# Altium schematic sheet MCU_IO.SchDoc
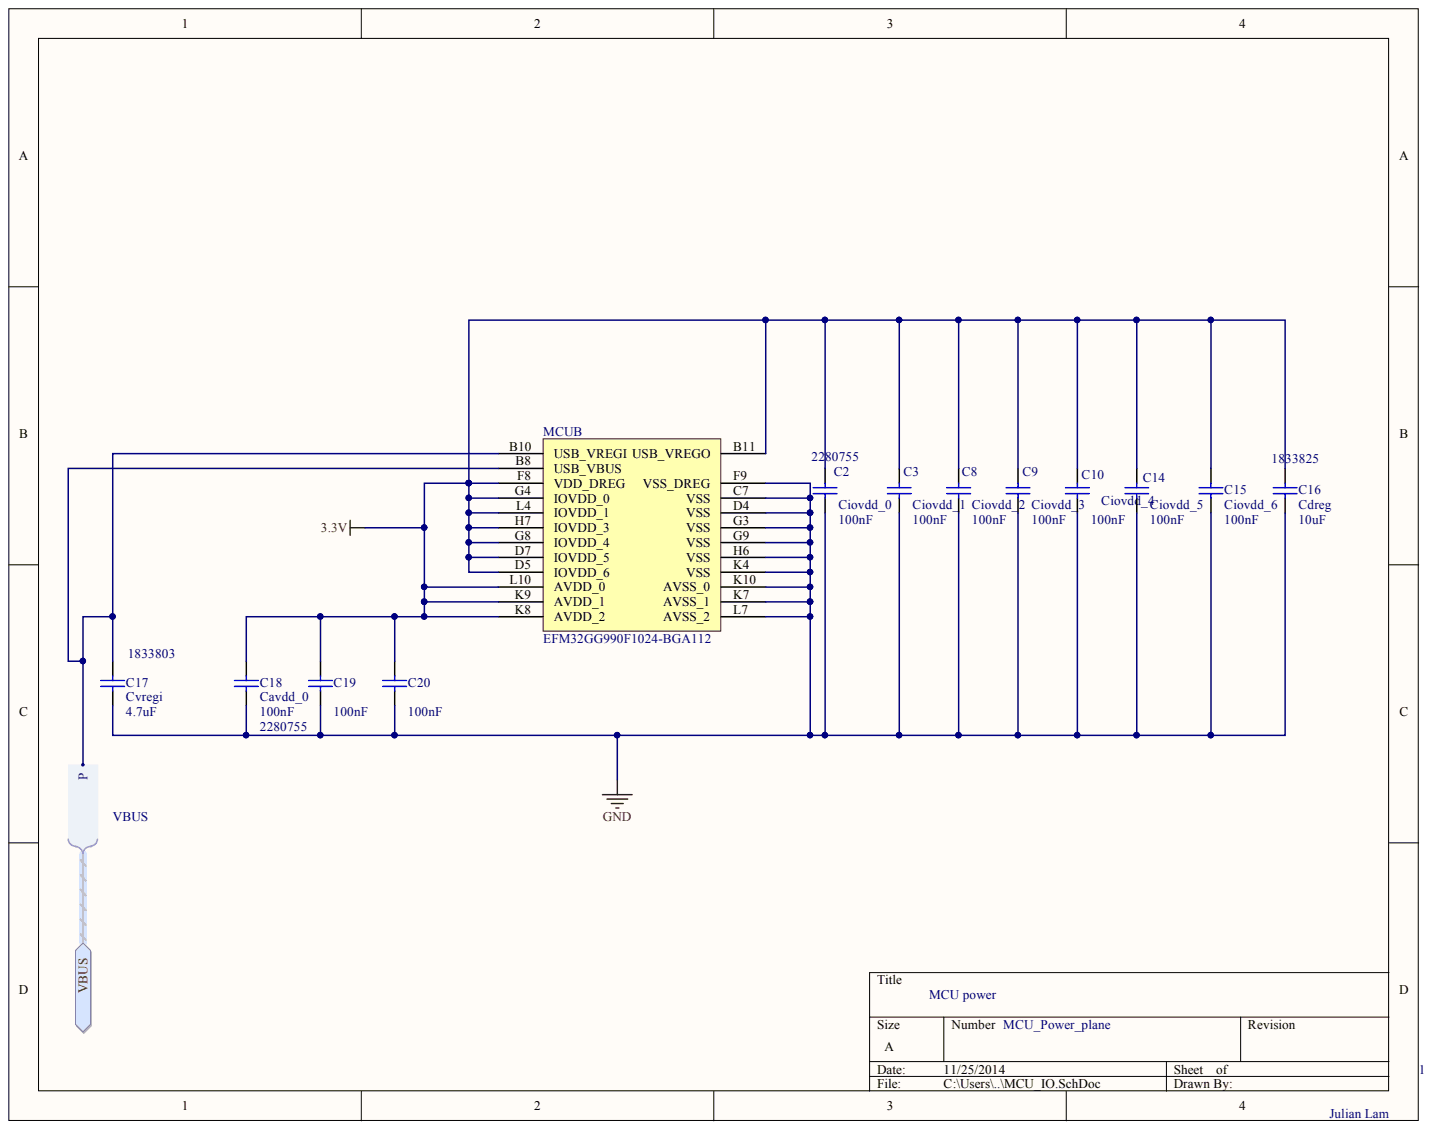
[diagram p0: MCU_IO.SchDoc]

## Parts
C2  Ciovdd_0  value 100nF  Supplier Part Number 1: 2280755  [C1206]
C3  Ciovdd_1  value 100nF  [C1206]
C8  Ciovdd_2  value 100nF  [C1206]
C9  Ciovdd_3  value 100nF  [C1206]
C10  Ciovdd_4  value 100nF  [C1206]
C14  Ciovdd_5  value 100nF  [C1206]
C15  Ciovdd_6  value 100nF  [C1206]
C16  Cdreg  value 10uF  Supplier Part Number 1: 1833825  [C1206]
C17  Cvregi  value 4.7uF  Supplier Part Number 1: 1833803  [C1206]
C18  Cavdd_0  value 100nF  Supplier Part Number 1: 2280755  [C1206]
C19  value 100nF  Supplier Part Number 1: 2280755  [C1206]  (hidden comment: Cavdd_1)
C20  value 100nF  [C1206]  (hidden comment: Cavdd_2)
MCU  EFM32GG990F1024-BGA112  [ENMR-BGA112]  (part B)

## Ports
VBUS, VBUS.P

## Nets
3.3V: C2.2 C3.2 C8.2 C9.2 C10.2 C14.2 C15.2 C16.2 C18.2 C19.2 C20.1 MCU.B11(USB_VREGO) MCU.D5(IOVDD_6) MCU.D7(IOVDD_5) MCU.F8(VDD_DREG) MCU.G4(IOVDD_0) MCU.G8(IOVDD_4) MCU.H7(IOVDD_3) MCU.K8(AVDD_2) MCU.K9(AVDD_1) MCU.L4(IOVDD_1) MCU.L10(AVDD_0)
GND: C2.1 C3.1 C8.1 C9.1 C10.1 C14.1 C15.1 C16.1 C17.1 C18.1 C19.1 C20.2 MCU.C7(VSS) MCU.D4(VSS) MCU.F9(VSS_DREG) MCU.G3(VSS) MCU.G9(VSS) MCU.H6(VSS) MCU.K4(VSS) MCU.K7(AVSS_1) MCU.K10(AVSS_0) MCU.L7(AVSS_2)
VBUS: C17.2 MCU.B8(USB_VBUS) MCU.B10(USB_VREGI) ; port VBUS

## Library data of the parts
C2 C3 C8-C10 C14 C15 C18-C20 = Cap Semi: Capacitor (Semiconductor SIM Model); Manufacturer: AVX; Manufacturer Part Number: 02016D104KAT2A; Capacitance: 0.1; Capacitance Tolerance: ± 10%; Capacitor Case Style: 0201 [0603 Metric]; Capacitor Terminals: SMD; Dielectric Characteristic: X5; Reel Quantity: 15000; SVHC: No SVHC (16-Dec-2013); Voltage Rating: 6.3; Capacitance Tolerance ±: ± 10%; Ceramic Capacitor Case: 0201 [0603 Metric]; Component Kind: Standard; ComponentLink1Description: http://www.farnell.com/datasheets/1666675.pdf; ComponentLink1URL: http://www.farnell.com/datasheets/1666675.pdf; LatestRevisionDate: 29-May-2009; LatestRevisionNote: IPC-7351 Footprint Added.; Library Name: Miscellaneous Devices.IntLib; MSL: MSL 1 - Unlimited; Operating Temperature Max: 85; Operating Temperature Min: -55; Operating Temperature Range: -55°C to +85; PackageDescription: Chip Capacitor; PackageReference: C1206; Packaging: Tape & Reel; Pricing 1: 15000=0.0827, 75000=0.062, 150000=0.0517 (EUR); Product Range: -; Published: 8-Jun-2000; RoHS: YES; Signal Integrity: Cap Semi; Simulation: CAP; Stock 1: 30000; Supplier 1: Farnell; Publisher: Altium Limited
  C3 C8-C10 C14 C15 C20: Supplier Part Number 1: 2280755
C16 = Cap Semi: Capacitor (Semiconductor SIM Model); Manufacturer: AVX; Manufacturer Part Number: 12063D106KAT2A; Capacitance: 10; Capacitance Tolerance: ± 10%; Capacitor Case Style: 1206 [3216 Metric]; Capacitor Terminals: SMD; Dielectric Characteristic: X5; SVHC: No SVHC (16-Dec-2013); Voltage Rating: 25; Capacitance Tolerance ±: ± 10%; Ceramic Capacitor Case: 1206 [3216 Metric]; Component Kind: Standard; ComponentLink1Description: http://www.farnell.com/datasheets/1796776.pdf; ComponentLink1URL: http://www.farnell.com/datasheets/1796776.pdf; LatestRevisionDate: 29-May-2009; LatestRevisionNote: IPC-7351 Footprint Added.; Library Name: Miscellaneous Devices.IntLib; MSL: MSL 1 - Unlimited; Operating Temperature Max: 85; Operating Temperature Min: -55; Operating Temperature Range: -55°C to +85; PackageDescription: Chip Capacitor; PackageReference: C1206; Packaging: Cut Tape; Pricing 1: 10=3.58, 100=2.42, 500=1.21, 1000=1, 2000=0.8 (EUR); Product Range: -; Published: 8-Jun-2000; RoHS: YES; Series: Hi-Cap MLCC<!--FANDB--><div class="features-and-benefits-container"></div>; Signal Integrity: Cap Semi; Simulation: CAP; Stock 1: 16889; Supplier 1: Farnell; Publisher: Altium Limited
C17 = Cap Semi: Capacitor (Semiconductor SIM Model); Manufacturer: AVX; Manufacturer Part Number: 06036D475KAT2A; Component Kind: Standard; ComponentLink1Description: http://www.farnell.com/datasheets/1796776.pdf; ComponentLink1URL: http://www.farnell.com/datasheets/1796776.pdf; LatestRevisionDate: 29-May-2009; LatestRevisionNote: IPC-7351 Footprint Added.; Library Name: Miscellaneous Devices.IntLib; PackageDescription: Chip Capacitor; PackageReference: C1206; Pricing 1: 10=1.65, 100=1.02, 500=0.44, 1000=0.36, 2000=0.29 (EUR); Published: 8-Jun-2000; RoHS: YES; Signal Integrity: Cap Semi; Simulation: CAP; Stock 1: 17276; Supplier 1: Farnell; Publisher: Altium Limited
MCU = CMP-0270-00024-1: EFM32 Giant Gecko, M3 ARM Cortex CPU, 1024 KB Flash, 128 KB RAM, 1.85 - 3.8 V Supply, 48 MHz Max Speed, -40 to 85 degC, 112-Pin BGA, RoHS Compliant; Flash (KB): 1024; GPIO: 87; LCD: 8x34; LESENSE: Y; Max Speed (MHz): 48; OPAMPS: 3; RAM (KB): 128; ARM Cortex CPU: M3; Component Kind: Standard; ComponentLink1Description: Manufacturer URL; ComponentLink1URL: http://www.energymicro.com/; ComponentLink2Description: Datasheet; ComponentLink2URL: http://cdn.energymicro.com/dl/devices/pdf/d0046_efm32gg990_datasheet.pdf; ComponentLink3Description: Package Specification; ComponentLink3URL: http://cdn.energymicro.com/dl/devices/pdf/d0007_efm32g290_datasheet.pdf; DatasheetVersion: Rev 1.00; Generic Part Number: EFM32GG990; Library Name: Altium Content Vault; Mounting Technology: Surface Mount; PackageDescription: 112-Pin Ball Grid Array, Body 10 x 10 mm, Pitch 0.8 mm; PackageReference: BGA112; PackageVersion: Rev. 97SPP01315A_X03_06Jun11; PartNumber: EFM32GG990F1024-BGA112; Subcategory: EFM32 Giant Gecko; Supply Voltage (V): 1.85 - 3.8; USB: Y
